# Altium schematic sheet Schematic_Aarshon.SchDoc(Schematic_Aarshon)
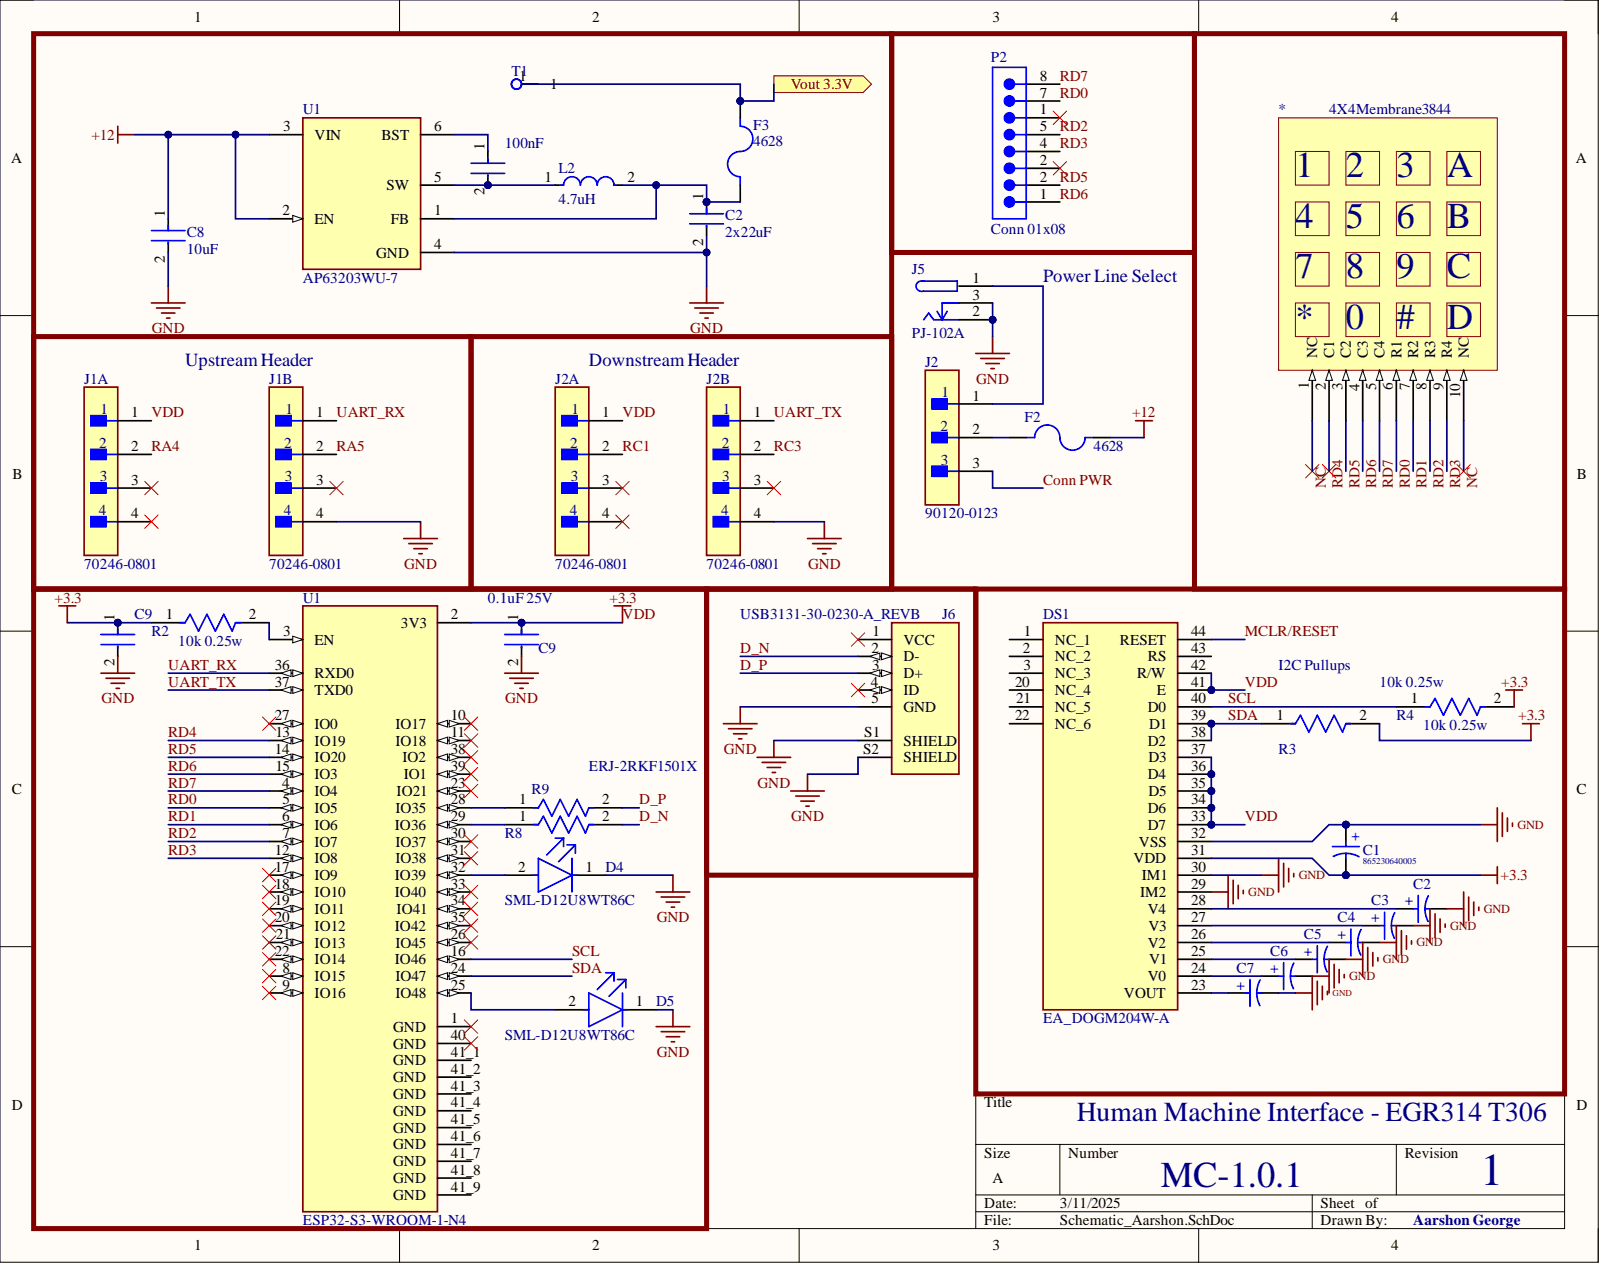
[diagram p0: Schematic_Aarshon.SchDoc]

## Parts
100nF  value 100nF
*  4X4Membrane3844
C1  865230640005
C2  2x22uF
C2  (no value)
C3  (no value)
C4  (no value)
C5  (no value)
C6  (no value)
C7  (no value)
C8  10uF
C9  (no value)
C9  0.1uF 25V  Rated DC Voltage (URdc): 25V
D4  SML-D12U8WT86C
D5  SML-D12U8WT86C
DS1  EA_DOGM204W-A
F2  4628
F3  4628
J1A  70246-0801
J1B  70246-0801
J2  90120-0123
J2A  70246-0801
J2B  70246-0801
J5  PJ-102A
J6  USB3131-30-0230-A_REVB
L2  4.7uH
P2  Conn 01x08
R2  10k 0.25w
R3  10k 0.25w
R4  10k 0.25w
R8  (no value)
R9  ERJ-2RKF1501X
T1  (no value)
U1  ESP32-S3-WROOM-1-N4
U1  AP63203WU-7

## Ports
Vout 3.3V

## Nets
+12: C8.1 F2.2 U1.2 U1.3
GND: C1.1 C2.2 C3.2 C4.2 C5.2 C6.2 C7.2 C8.2 C9.2 D4.1 D5.1 DS1.29(IM2) DS1.30(IM1) DS1.32(VSS) J1B.4 J2B.4 J5.2 J5.3 J6.5(GND) J6.S1(SHIELD) J6.S2(SHIELD) U1.4
Conn PWR: J2.3
D_N: J6.2(D-) R8.2
D_P: J6.3(D+) R9.2
MCLR/RESET: DS1.44(RESET)
RA4: J1A.2
RA5: J1B.2
RC1: J2A.2
RC3: J2B.2
RD0: P2.7 U1.5
RD1: U1.6
RD2: P2.5 U1.7(IO7)
RD3: P2.4 U1.12(IO8)
RD4: U1.13(IO19)
RD5: P2.2 U1.14(IO20)
RD6: P2.1 U1.15(IO3)
RD7: P2.8 U1.4
SCL: DS1.40(D0) R4.1 U1.16(IO46)
SDA: DS1.38(D2) DS1.39(D1) R3.1 U1.24(IO47)
UART_RX: J1B.1 U1.36(RXD0)
UART_TX: J2B.1 U1.37(TXD0)
VDD: C1.2 C9.1 DS1.31(VDD) DS1.33(D7) DS1.34(D6) DS1.35(D5) DS1.36(D4) DS1.37(D3) DS1.41(E) DS1.42(R/W) J1A.1 J2A.1 R2.1 R3.2 R4.2 U1.2
Vout 3.3V: F3.2 T1.1 ; port Vout 3.3V
Net100nF_1: 100nF.1 U1.6
Net100nF_2: 100nF.2 L2.1 U1.5
NetC2_1: C2.1 DS1.28(V4) F3.1 L2.2 U1.1
NetC3_1: C3.1 DS1.27(V3)
NetC4_1: C4.1 DS1.26(V2)
NetC5_1: C5.1 DS1.25(V1)
NetC6_1: C6.1 DS1.24(V0)
NetC7_1: C7.1 DS1.23(VOUT)
NetD4_2: D4.2 U1.32(IO39)
NetD5_2: D5.2 U1.25(IO48)
NetF2_1: F2.1 J2.2
NetJ2_1: J2.1 J5.1
NetR2_2: R2.2 U1.3
NetR8_1: R8.1 U1.29(IO36)
NetR9_1: R9.1 U1.28(IO35)
NC:

Not connected: * 1(NC) 2(C1) 3(C2) 4(C3) 5(C4) 6(R1) 7(R2) 8(R3) 9(R4) 10(NC); DS1 1(NC_1) 2(NC_2) 3(NC_3) 20(NC_4) 21(NC_5) 22(NC_6) 43(RS); J1A 3 4; J1B 3; J2A 3 4; J2B 3; J6 1(VCC) 4(ID); U1 8(IO15) 9(IO16) 10(IO17) 11(IO18) 17(IO9) 18(IO10) 19(IO11) 20(IO12) 21(IO13) 22(IO14) 23(IO21) 26(IO45) 27(IO0) 30(IO37) 31(IO38) 33(IO40) 34(IO41) 35(IO42) 38(IO2) 39(IO1) 40(GND) 41_1(GND) 41_2(GND) 41_3(GND) 41_4(GND) 41_5(GND) 41_6(GND) 41_7(GND) 41_8(GND) 41_9(GND)

## Library data of the parts
100nF = CMP-00010-1: Ceramic Capacitor, Multilayer, Ceramic, 100V, 10% +Tol, 10% -Tol, X7R, 15% TC, 0.1uF, Surface Mount, 1206; Multilayer: Yes; Reference Standard: AEC-Q200; Capacitor Type: Ceramic; Case/Package: 1206; Component Kind: Standard; Dielectric Material: Ceramic; Height: 1.27mm; JESD-609 Code: e3; Length: 3.2mm; Max Operating Temperature: 125°C; Min Operating Temperature: -55°C; Mounting Technology: Surface Mount; Package Shape: Rectangular; Package Style: SMTMeter; Packaging: Tape and Reel; Pins: 2; Rated DC Voltage (URdc): 100V; Reach SVHC Compliant: Yes; RoHS Compliant: Yes; Temperature Characteristics Code: X7R; Terminal Finish: Nickel; Termination: Wraparound; Tolerance  (fill in as +-): 10%; Tolerance (fill in as +-): 10%; Width: 1.6mm; Library Name: MacroChip
* = CMP-00001-1: Adapter; TNC Male to BNC Female; Brass; Brass (Male), Beryllium Copper (Female); Component Kind: Standard; Library Name: MacroChip
C1-C7 = CMP-00009-1: WCAP-AS5H Aluminum Electrolytic Capacitors; Component Kind: Standard; Library Name: MacroChip
C2 = CMP-00013-1: Ceramic Capacitor, Multilayer, Ceramic, 35V, 20% +Tol, 20% -Tol, X5R, 15% TC, 22uF, Surface Mount, 1206; Multilayer: Yes; Capacitor Type: Ceramic; Case/Package: 1206; Component Kind: Standard; Dielectric Material: Ceramic; Height: 1.6mm; JESD-609 Code: e3; Length: 3.2mm; Max Operating Temperature: 85°C; Min Operating Temperature: -55°C; Mounting Technology: Surface Mount; Package Shape: Rectangular; Package Style: SMTMeter; Packaging: Tape and Reel; Pins: 2; Rated DC Voltage (URdc): 35V; Reach SVHC Compliant: Yes; RoHS Compliant: Yes; Temperature Characteristics Code: X5R; Terminal Finish: Nickel; Termination: Wraparound; Tolerance  (fill in as +-): 20%; Tolerance (fill in as +-): 20%; Value: 22uF; Width: 1.6mm; Library Name: MacroChip
C8 C9 = CMP-00010-1: Ceramic Capacitor, Multilayer, Ceramic, 100V, 10% +Tol, 10% -Tol, X7R, 15% TC, 0.1uF, Surface Mount, 1206; Multilayer: Yes; Reference Standard: AEC-Q200; Value: 100nF; Capacitor Type: Ceramic; Case/Package: 1206; Component Kind: Standard; Dielectric Material: Ceramic; Height: 1.27mm; JESD-609 Code: e3; Length: 3.2mm; Max Operating Temperature: 125°C; Min Operating Temperature: -55°C; Mounting Technology: Surface Mount; Package Shape: Rectangular; Package Style: SMTMeter; Packaging: Tape and Reel; Pins: 2; Rated DC Voltage (URdc): 100V; Reach SVHC Compliant: Yes; RoHS Compliant: Yes; Temperature Characteristics Code: X7R; Terminal Finish: Nickel; Termination: Wraparound; Tolerance  (fill in as +-): 10%; Tolerance (fill in as +-): 10%; Width: 1.6mm; Library Name: MacroChip
C9 = CMP-00015-1: Ceramic Capacitor, Multilayer, Ceramic, 25V, 10% +Tol, 10% -Tol, X7R, 15% TC, 0.1uF, Surface Mount, 1206; Multilayer: Yes; Value: 100nF; Capacitor Type: Ceramic; Case/Package: 1206; Component Kind: Standard; Dielectric Material: Ceramic; Height: 0.78mm; JESD-609 Code: e3; Length: 3.2mm; Max Operating Temperature: 125°C; Min Operating Temperature: -55°C; Mounting Technology: Surface Mount; Package Shape: Rectangular; Package Style: SMTMeter; Packaging: Tape and Reel; Pins: 2; Reach SVHC Compliant: Yes; RoHS Compliant: Yes; Temperature Characteristics Code: X7R; Terminal Finish: Nickel; Termination: Wraparound; Tolerance  (fill in as +-): 10%; Tolerance (fill in as +-): 10%; Width: 1.6mm; Library Name: MacroChip
D4 D5 = CMP-08952-000014-1: LED RED 1608 SMD; Manufacturer: Rohm; Automotive Grade: Grade 3; Forward Current: 20mA; Forward Voltage: 2.2V; Led Orientation: Top View; Luminous Intensity: 63mcd; Power Dissipation: 54mW; Reverse Voltage: 5V; Wavelength: 620nm; Automotive: Yes; Category: Diode; Colour: Red; Component Kind: Standard; ComponentLink2Description: Datasheet; ComponentLink2URL: https://fscdn.rohm.com/en/products/databook/datasheet/opto/led/chip_mono/sml-d12x8(c)-e.pdf; Device Class L1: Optoelectronics; Device Class L2: LEDs; Device Class L3: unset; Digikey Description: LED RED 1608 SMD; Height: 0.65mm; Lead Free: Yes; Library Name: Altium Content Vault; Manufacturer Part Number: SML-D12U8WT86C; Mouser Description: LED Uni-Color Red Automotive 2-Pin Chip 0603(1608Metric) T/R; Octopart: https://octopart.com/sml-d12u8wt86c-rohm-77740214; Package: SML-D12; RoHS: TRUE; Temperature: -40°C to +85°C; Temperature Range High: +85°C; Temperature Range Low: -40°C
DS1 = EA_DOGM204W-A: Display; Manufacturer_Name: Display Visions; Manufacturer_Part_Number: EA DOGM204W-A; Component Kind: Standard; Datasheet Link: http://www.lcd-module.com/fileadmin/eng/pdf/doma/dogm204e.pdf; Height: 2mm; Mouser Part Number: 790-DOGM204WA; Mouser Price/Stock: https://www.mouser.co.uk/ProductDetail/Display-Visions/EA-DOGM204W-A?qs=ZP3UR5XsUodtHsgchgGPcw%3D%3D
F2 F3 = CMP-006-000000-1: 5mm Slim Line Fuse holder; MF: Keystone; Check_prices: https://www.snapeda.com/parts/4628/Keystone/view-part/?ref=eda; Component Kind: Standard; Component Type: Standard; Design Item ID: 4628; MP: 4628; Package: None; Pin Count: 2; SnapEDA_Link: https://www.snapeda.com/parts/4628/Keystone/view-part/?ref=snap; Source: 4628.SchLib; Library Name: MacroChip
J1A J1B J2A J2B = CMP-00032-1: Connector Header Top Entry, PH Series, 4 Position, 2.00mm, Through Hole, Press-Fit, Solder, Ivory; Component Kind: Standard; Library Name: MacroChip
J2 = CMP-00025-1: Board Connector, 3 Contact(s), 1 Row(s), Male, Straight, 0.1 inch Pitch, Solder Terminal, Black Insulator, Receptacle; Body Depth: 0.098inch; Body Length: 0.3inch; Contact Pattern: RECTANGULAR; Contact Resistance: 20mR; Contact Style: SQ PIN-SKT; Dielectric Withstanding Voltage (DC): 350V; DIN Conformance: No; Insertion Force-Max: 3.0024N; Insulation Resistance: 5GR; Mating Information: MULTIPLE MATING PARTS AVAILABLE; Number Of Connectors: 1; Number of Contacts: 3; Option: General Purpose; Plating Thickness: 160uin; Rated Current (Signal): 3A; Reference Standard: UL; Reliability: COMMERCIAL; Withdrawl Force-Min: 0.1946N; Body Breadth: 0.1inch; Body/Shell Style: RECEPTACLE; Component Kind: Standard; Connector Type: BOARD CONNECTOR; Contact Finish - Mating: NOT SPECIFIED; Contact Finish - Termination: Matte Tin (Sn) - with Nickel (Ni) barrier; Contact Gender: Male; Contact Material: Copper Alloy; Filter Feature: No; Flammability Rating: UL94 V-0; IEC Conformance: No; Insulation Color: Black; Insulation Material: Glass; JESD-609 Code: e3; Mating Contact Pitch: 0.1inch; Max Operating Temperature: 125°C; MIL Conformance: No; Min Operating Temperature: -55°C; Mixed Contacts: No; Mounting Style: STRAIGHT; Mounting Technology: Board; Number Of PCB Rows: 1; Number of Rows Loaded: 1; PCB Contact Pattern: RECTANGULAR; Reach SVHC Compliant: Yes; RoHS Compliant: Yes; Terminal Length: 0.114inch; Terminal Pitch: 2.54mm; Termination: Solder; Library Name: MacroChip
J5 = CMP-00031-1: 2.0 x 6.5 mm, 2.5 A, Horizontal, Through Hole, Tapered Pins, Dc Power Jack Connector; Component Kind: Standard; Library Name: MacroChip
J6 = CMP-008-000002-1: USB - micro B USB 2.0 Receptacle Connector 5 Position Through Hole; Availability: Unavailable; Component Kind: Standard; Component Type: Standard; Design Item ID: USB3131-30-0230-A_REVB; MP: USB3131-30-0230-A_REVB; Package: None; Pin Count: 7; Price: None; Source: USB3131-30-0230-A_REVB.SchLib; Library Name: MacroChip
L2 = CMP-00011-1: General Purpose Inductor, 4.7uH, 20%, 1 Element, Composite-Core, SMD, 2525; Case/Size Code: 2726; Channels: 1; Core Material: Ferrite; DC Resistance (DCR): 30.3mR; Inductor Application: HIGH CURRENT INDUCTOR; Max Current Rating: 6.5A; Package Height: 5mm; Package Length: 6.86mm; Package Width: 6.47mm; Shape/Size Description: RECTANGULAR PACKAGE; Shielding: Shielded; Test Frequency: 100kHz; Component Kind: Standard; Construction: Shielded; Inductance-Nom (L): 4.7uH; Inductor Type: GENERAL PURPOSE INDUCTOR; JESD-609 Code: e3; Max Operating Temperature: 125°C; Min Operating Temperature: -55°C; Package Style: SMTMeter; Packaging: Tape and Reel; Pins: 2; Reach SVHC Compliant: Yes; RoHS Compliant: Yes; Surface Mount: Yes; Terminal Finish: Nickel; Terminal Placement: Dual Ended; Termination: Wraparound; Tolerance: 20%; Library Name: MacroChip
P2 = CMP-00017-1: Board Connector, 8 Contact(s), 1 Row(s), Male, Straight, 0.1 inch Pitch, Solder Terminal, Locking, Black Insulator, Plug; Manufacturer Series: 613; Body Length: 0.8inch; Contact Pattern: RECTANGULAR; Contact Resistance: 20mR; Contact Style: SQ PIN-SKT; Dielectric Withstanding Voltage (AC): 500V; Insulation Resistance: 1GR; Number Of Connectors: 1; Number of Contacts: 8; Rated Current (Signal): 3A; Reference Standard: UL; Reliability: COMMERCIAL; Body Breadth: 0.1inch; Body Depth: 0.1inch; Body/Shell Style: PLUG; Component Kind: Standard; Connector Type: BOARD CONNECTOR; Contact Finish - Mating: AU; Contact Finish - Termination: Gold (Au) - with Nickel (Ni) barrier; Contact Gender: Male; Contact Material: Copper Alloy; Insulation Color: Black; Insulation Material: Polybutylene; JESD-609 Code: e4; Mating Contact Pitch: 0.1inch; Max Operating Temperature: 125°C; Min Operating Temperature: -40°C; Mounting Option 1: LOCKING; Mounting Style: STRAIGHT; Mounting Technology: Board; Number of Rows Loaded: 1; PCB Contact Pattern: RECTANGULAR; Reach SVHC Compliant: Yes; RoHS Compliant: Yes; Terminal Length: 0.118inch; Terminal Pitch: 2.54mm; Termination: Solder; Library Name: MacroChip
R2-R4 = CMP-00022-1: Fixed Resistor, Metal Glaze/thick Film, 0.25W, 10000ohm, 200V, 5% +/-Tol, 200ppm/Cel, Surface Mount, 1206; Package Height: 0.6mm; Package Length: 3.2mm; Package Width: 1.6mm; Power: 250mW; Rated Temperature: 70°C; Temperature Coefficient (ppm/Cel): 200; Value: 10kR; Case/Package: 1206; Component Kind: Standard; Construction: Chip; JESD-609 Code: e3; Max Operating Temperature: 155°C; Min Operating Temperature: -55°C; Mounting Technology: Surface Mount; Package Shape: Rectangular; Packaging: Tape and Reel; Pins: 2; Reach SVHC Compliant: Yes; Resistor Type: FIXED RESISTOR; RoHS Compliant: Yes; Technology: RUTHENIUM OXIDE; Terminal Finish: Nickel; Termination: Wraparound; Tolerance: 5%; Working Voltage: 200V; Library Name: MacroChip
R8 R9 = CMP-2002-00693-2: RES SMD 1.5K OHM 1% 1/10W 0402; Max Power Dissipation: 100mW; Resistance: 1.5kR; Temperature Coefficient: 100ppm/°C; Value: 1.5kR; Voltage Rating: 50V; Case Code (Imperial): 0402; Case Code (Metric): 1005; Case/Package: 0402; Component Kind: Standard; Construction: Rectangular; Contact Plating: Tin; Depth: 0.5mm; Features: Automotive AEC-Q200; Height: 0.35mm; Lead Free: Lead Free; Length: 1mm; Library Name: Altium Content Vault; Max Operating Temperature: 155°C; Military Standard: Not; Min Operating Temperature: -55°C; Mount: Surface Mount; Number of Pins: 2; Number of Terminations: 2; Package Quantity: 1; Packaging: Tape and Reel; Power Rating: 100mW; Radiation Hardening: No; REACH SVHC: No SVHC; Resistance Tolerance: 1%; Resistor Type: Thick Film; RoHS Compliant: Yes; Series: ERJ; Size Code: 0402; Technology: THICK FILM; Termination: SMD/SMT; Tolerance: 1%; Voltage Rating (DC): 50V; Width: 0.02inch
T1 = CMP-00030-3: tht test point; Component Kind: Standard; Library Name: MacroChip
U1 = CMP-002-000001-1: MF: {'manufacturer': u'Espressif Systems'}; Availability: Unavailable; Component Kind: Standard; Component Type: Standard; Design Item ID: ESP32-S3-WROOM-1-N4; MP: ESP32-S3-WROOM-1-N4; Package: None; Pin Count: 49; Price: None; Source: ESP32-S3-WROOM-1-N4.SchLib; Library Name: MacroChip
U1 = CMP-00004-1: Switching Regulator; Component Kind: Standard; Library Name: MacroChip
